# Altium schematic sheet PLUS.SchDoc(PLUS)
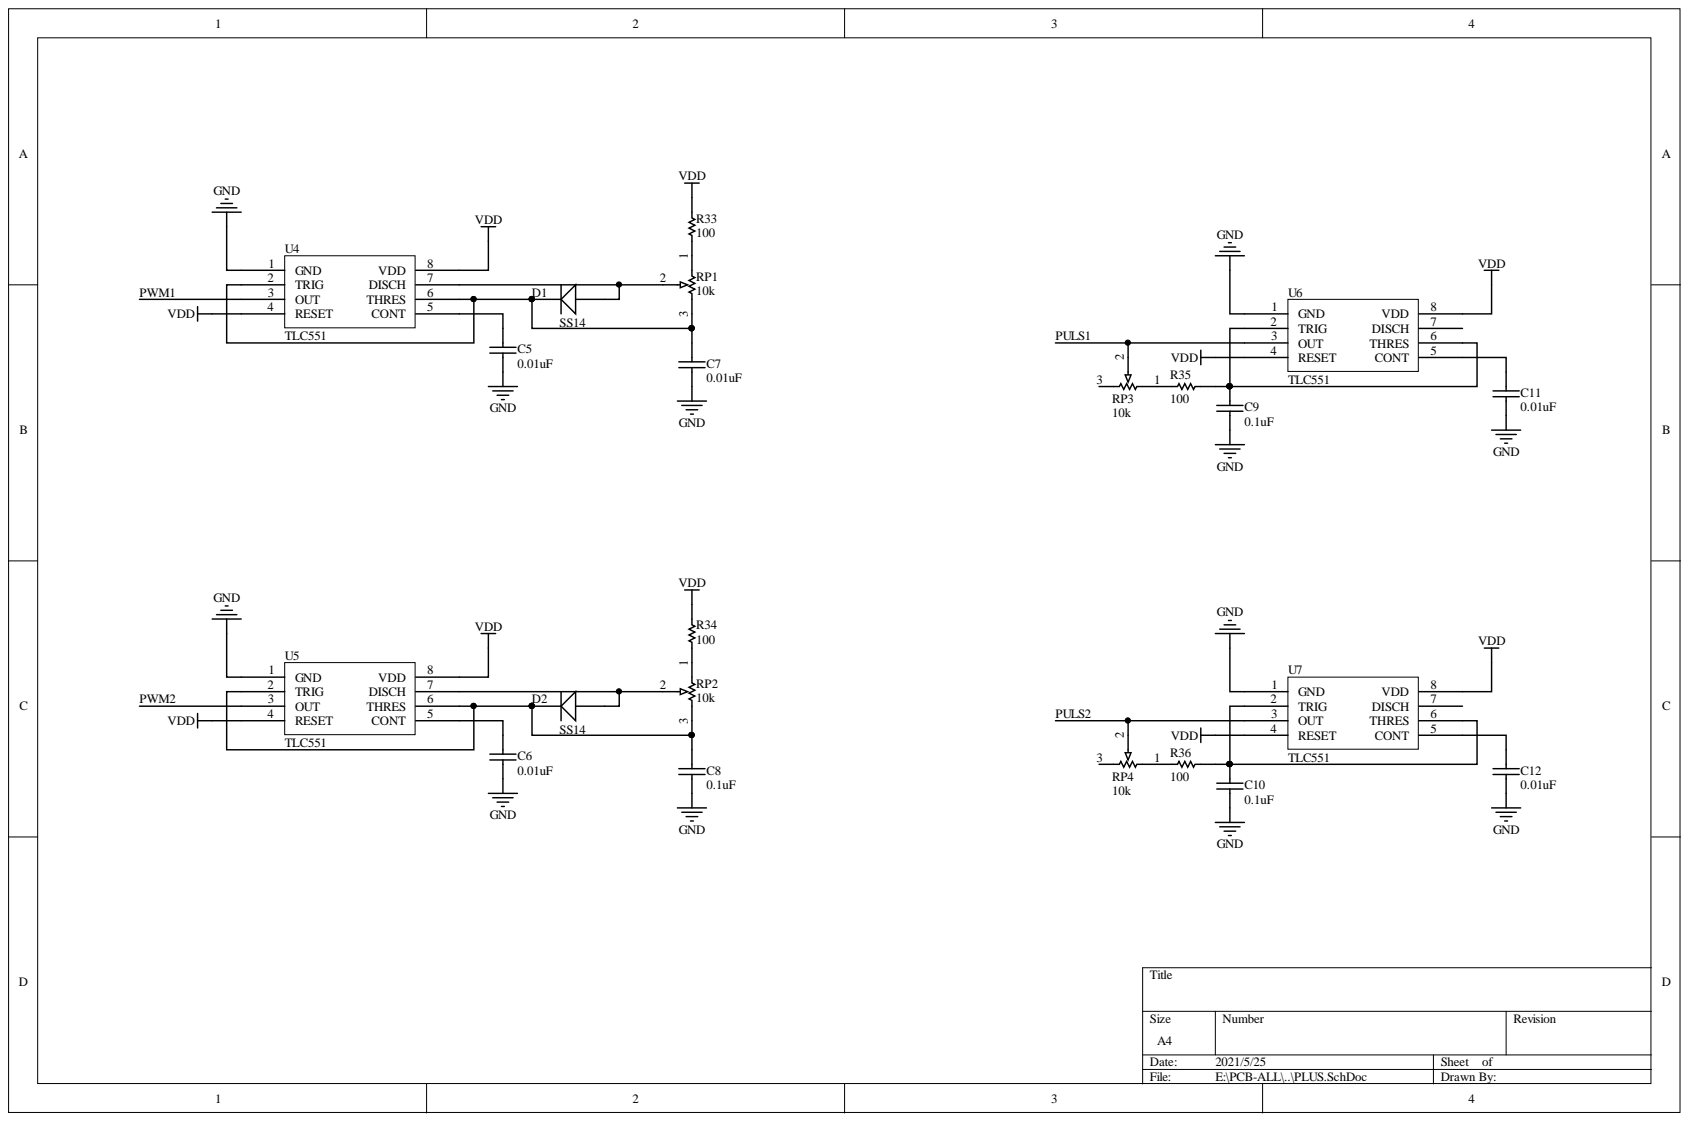
[diagram p0: PLUS.SchDoc]

## Parts
C5  0.01uF  [C0805]
C6  0.01uF  [C0805]
C7  0.01uF  [C0805]
C8  0.1uF  [C0805]
C9  0.1uF  [C0805]
C10  0.1uF  [C0805]
C11  0.01uF  [C0805]
C12  0.01uF  [C0805]
D1  SS14  [diode-smt]
D2  SS14  [diode-smt]
R33  100  [R0805]
R34  100  [R0805]
R35  100  [R0805]
R36  100  [R0805]
RP1  10k  [RES-3296W]
RP2  10k  [RES-3296W]
RP3  10k  [RES-3296W]
RP4  10k  [RES-3296W]
U4  TLC551  [SO-8]
U5  TLC551  [SO-8]
U6  TLC551  [SO-8]
U7  TLC551  [SO-8]

## Nets
GND: C5.2 C6.2 C7.2 C8.2 C9.2 C10.2 C11.2 C12.2 U4.1(GND) U5.1(GND) U6.1(GND) U7.1(GND)
VDD: R33.1 R34.1 U4.4(RESET) U4.8(VDD) U5.4(RESET) U5.8(VDD) U6.4(RESET) U6.8(VDD) U7.4(RESET) U7.8(VDD)
PULS1: RP3.2 U6.3(OUT)
PULS2: RP4.2 U7.3(OUT)
PWM1: U4.3(OUT)
PWM2: U5.3(OUT)
NetC5_1: C5.1 U4.5(CONT)
NetC6_1: C6.1 U5.5(CONT)
NetC7_1: C7.1 D1.2 RP1.3 U4.2(TRIG) U4.6(THRES)
NetC8_1: C8.1 D2.2 RP2.3 U5.2(TRIG) U5.6(THRES)
NetC9_1: C9.1 R35.2 U6.2(TRIG) U6.6(THRES)
NetC10_1: C10.1 R36.2 U7.2(TRIG) U7.6(THRES)
NetC11_1: C11.1 U6.5(CONT)
NetC12_1: C12.1 U7.5(CONT)
NetD1_1: D1.1 RP1.2 U4.7(DISCH)
NetD2_1: D2.1 RP2.2 U5.7(DISCH)
NetR33_2: R33.2 RP1.1
NetR34_2: R34.2 RP2.1
NetR35_1: R35.1 RP3.1
NetR36_1: R36.1 RP4.1

Not connected: RP3 3; RP4 3; U6 7(DISCH); U7 7(DISCH)

## Library data of the parts
C5-C12 = CAP: Capacitor; 中文名称: 贴片电容; Component Kind: Standard; Library Name: 2014.SchLib
D1 D2 = DIODE: Code - JEDEC: DO-214-AA; Component Kind: Standard; Library Name: 2014.SchLib; Published: 8-Jun-2000; Revision: July-2002: Re-released for DXP Platform.; Publisher: Altium Limited
R33-R36 = Res1: Resistor; Value: 1K; Component Kind: Standard; Library Name: 2014.SchLib; Published: 8-Jun-2000; Revision: July-2002: Re-released for DXP Platform.; Signal Integrity: Res; Simulation: RESISTOR; Publisher: Altium Limited
RP1-RP4 = RPot: Potentiometer; Set Position: 0.5; Sim Note: Enter the Resistance value and the set point into parameter fields.; Value: 1K; Component Kind: Standard; LatestRevisionDate: 17-Jul-2002; LatestRevisionNote: Re-released for DXP Platform.; Library Name: Miscellaneous Devices.IntLib; PackageReference: VR5; Published: 8-Jun-2000; Simulation: POT; Publisher: Altium Limited
U4-U7 = NE555: Component Kind: Standard; Library Name: 2014.SchLib
